# Altium schematic sheet BALANCED_CHARGE.SchDoc
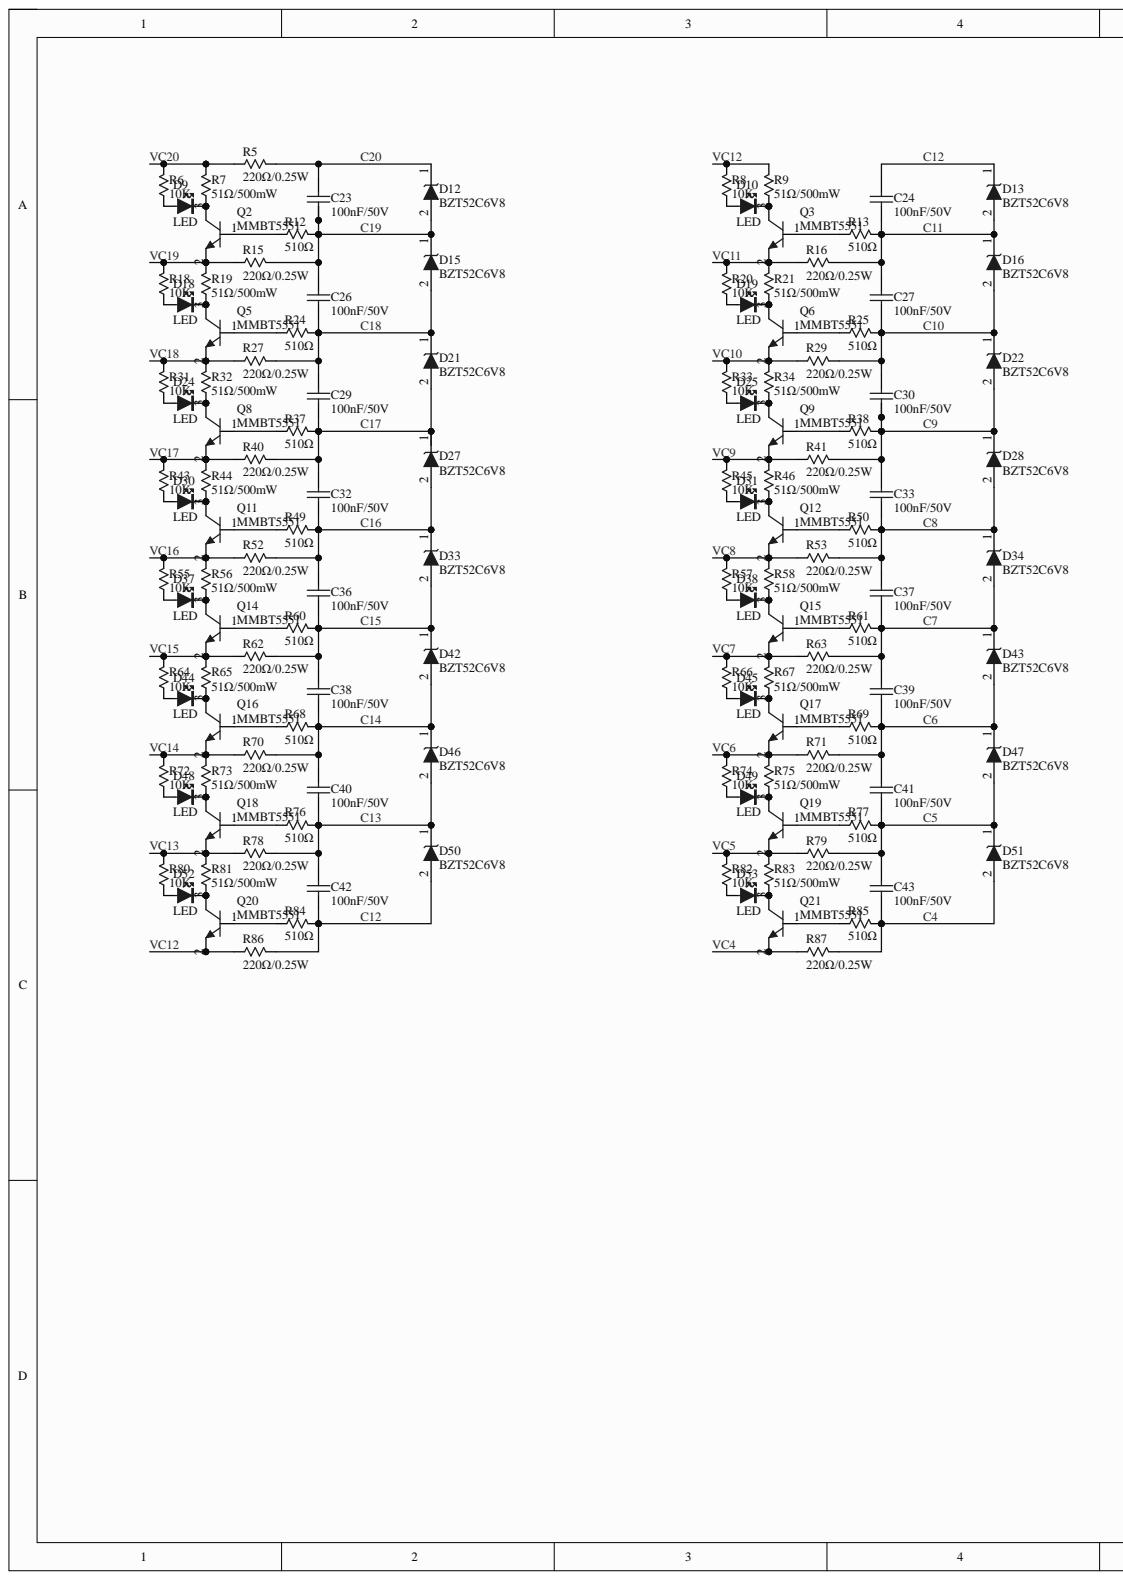
[diagram p0: BALANCED_CHARGE.SchDoc, left]
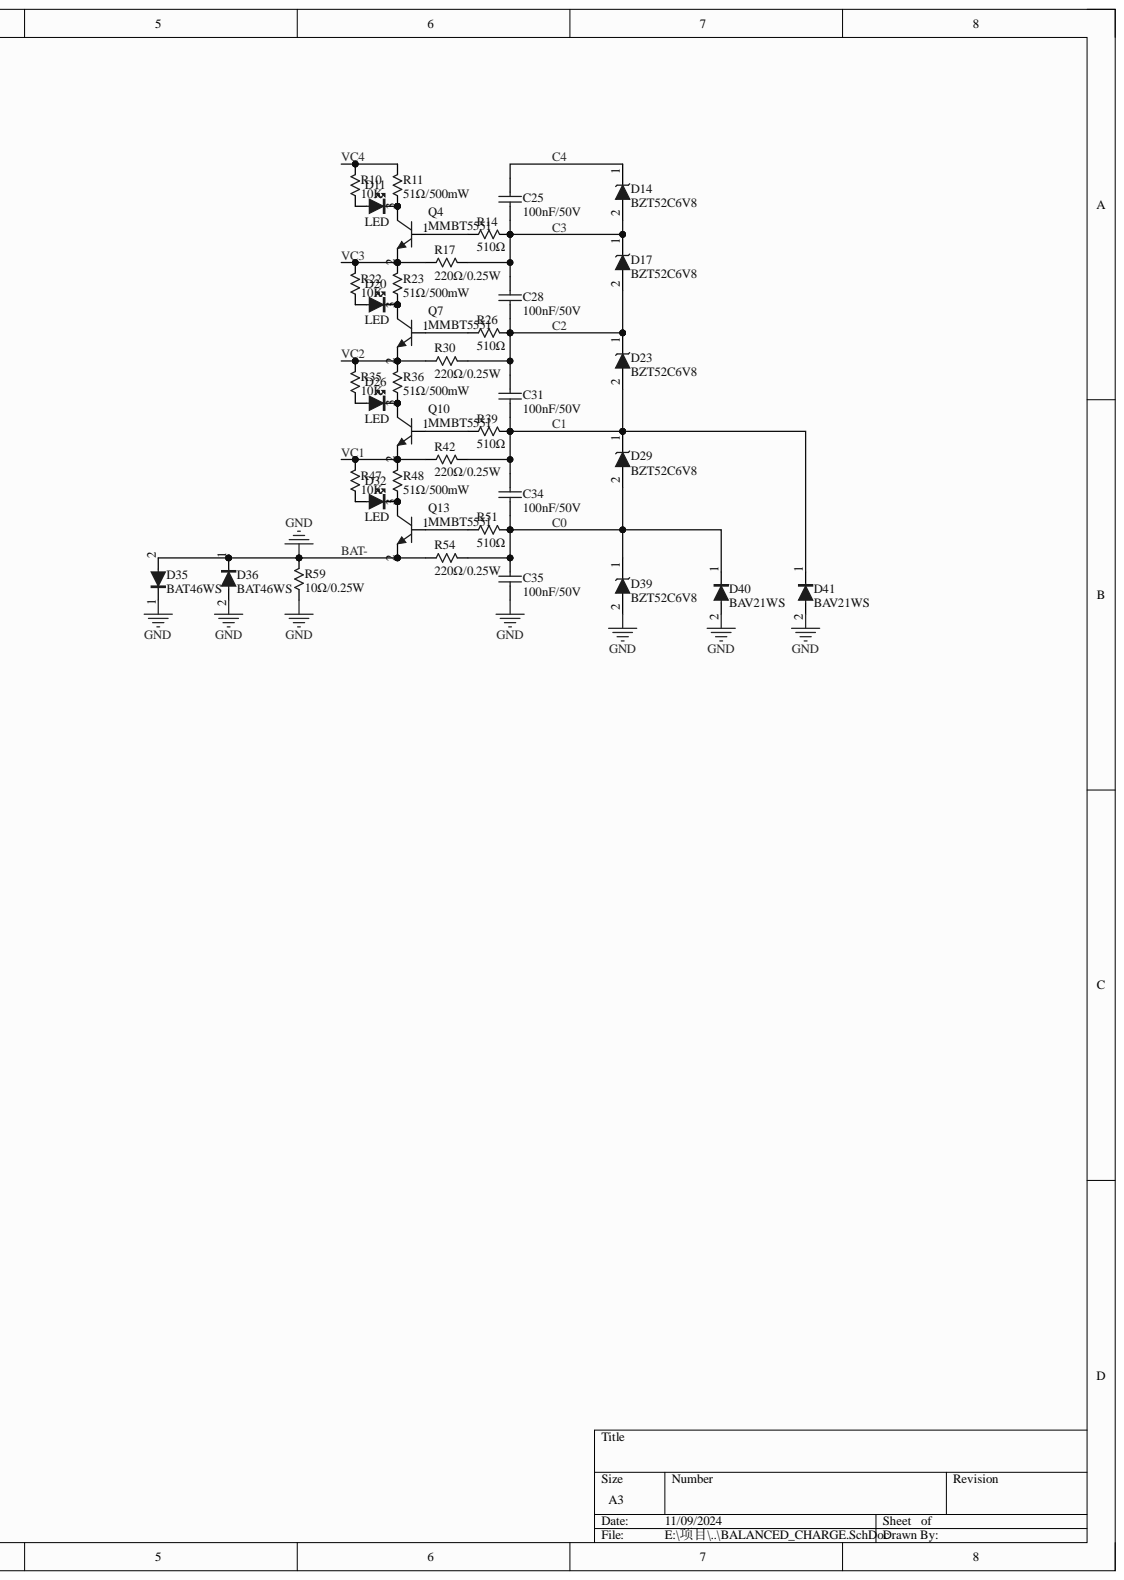
[diagram p1: BALANCED_CHARGE.SchDoc, right]

## Parts
C23  100nF/50V  [0603_C]
C24  100nF/50V  [0603_C]
C25  100nF/50V  [0603_C]
C26  100nF/50V  [0603_C]
C27  100nF/50V  [0603_C]
C28  100nF/50V  [0603_C]
C29  100nF/50V  [0603_C]
C30  100nF/50V  [0603_C]
C31  100nF/50V  [0603_C]
C32  100nF/50V  [0603_C]
C33  100nF/50V  [0603_C]
C34  100nF/50V  [0603_C]
C35  100nF/50V  [0603_C]
C36  100nF/50V  [0603_C]
C37  100nF/50V  [0603_C]
C38  100nF/50V  [0603_C]
C39  100nF/50V  [0603_C]
C40  100nF/50V  [0603_C]
C41  100nF/50V  [0603_C]
C42  100nF/50V  [0603_C]
C43  100nF/50V  [0603_C]
D9  LED  [LED-0603G]
D10  LED  [LED-0603G]
D11  LED  [LED-0603G]
D12  BZT52C6V8  [SOD-123]
D13  BZT52C6V8  [SOD-123]
D14  BZT52C6V8  [SOD-123]
D15  BZT52C6V8  [SOD-123]
D16  BZT52C6V8  [SOD-123]
D17  BZT52C6V8  [SOD-123]
D18  LED  [LED-0603G]
D19  LED  [LED-0603G]
D20  LED  [LED-0603G]
D21  BZT52C6V8  [SOD-123]
D22  BZT52C6V8  [SOD-123]
D23  BZT52C6V8  [SOD-123]
D24  LED  [LED-0603G]
D25  LED  [LED-0603G]
D26  LED  [LED-0603G]
D27  BZT52C6V8  [SOD-123]
D28  BZT52C6V8  [SOD-123]
D29  BZT52C6V8  [SOD-123]
D30  LED  [LED-0603G]
D31  LED  [LED-0603G]
D32  LED  [LED-0603G]
D33  BZT52C6V8  [SOD-123]
D34  BZT52C6V8  [SOD-123]
D35  BAT46WS  [SOD-323]
D36  BAT46WS  [SOD-323]
D37  LED  [LED-0603G]
D38  LED  [LED-0603G]
D39  BZT52C6V8  [SOD-123]
D40  BAV21WS  [SOD-323]
D41  BAV21WS  [SOD-323]
D42  BZT52C6V8  [SOD-123]
D43  BZT52C6V8  [SOD-123]
D44  LED  [LED-0603G]
D45  LED  [LED-0603G]
D46  BZT52C6V8  [SOD-123]
D47  BZT52C6V8  [SOD-123]
D48  LED  [LED-0603G]
D49  LED  [LED-0603G]
D50  BZT52C6V8  [SOD-123]
D51  BZT52C6V8  [SOD-123]
D52  LED  [LED-0603G]
D53  LED  [LED-0603G]
Q2  MMBT5551  [SOT-23-3]
Q3  MMBT5551  [SOT-23-3]
Q4  MMBT5551  [SOT-23-3]
Q5  MMBT5551  [SOT-23-3]
Q6  MMBT5551  [SOT-23-3]
Q7  MMBT5551  [SOT-23-3]
Q8  MMBT5551  [SOT-23-3]
Q9  MMBT5551  [SOT-23-3]
Q10  MMBT5551  [SOT-23-3]
Q11  MMBT5551  [SOT-23-3]
Q12  MMBT5551  [SOT-23-3]
Q13  MMBT5551  [SOT-23-3]
Q14  MMBT5551  [SOT-23-3]
Q15  MMBT5551  [SOT-23-3]
Q16  MMBT5551  [SOT-23-3]
Q17  MMBT5551  [SOT-23-3]
Q18  MMBT5551  [SOT-23-3]
Q19  MMBT5551  [SOT-23-3]
Q20  MMBT5551  [SOT-23-3]
Q21  MMBT5551  [SOT-23-3]
R5  220Ω/0.25W  [0603_R]
R6  10K  [0603_R]
R7  51Ω/500mW  [1206R]
R8  10K  [0603_R]
R9  51Ω/500mW  [1206R]
R10  10K  [0603_R]
R11  51Ω/500mW  [1206R]
R12  510Ω  [0603_R]
R13  510Ω  [0603_R]
R14  510Ω  [0603_R]
R15  220Ω/0.25W  [0603_R]
R16  220Ω/0.25W  [0603_R]
R17  220Ω/0.25W  [0603_R]
R18  10K  [0603_R]
R19  51Ω/500mW  [1206R]
R20  10K  [0603_R]
R21  51Ω/500mW  [1206R]
R22  10K  [0603_R]
R23  51Ω/500mW  [1206R]
R24  510Ω  [0603_R]
R25  510Ω  [0603_R]
R26  510Ω  [0603_R]
R27  220Ω/0.25W  [0603_R]
R29  220Ω/0.25W  [0603_R]
R30  220Ω/0.25W  [0603_R]
R31  10K  [0603_R]
R32  51Ω/500mW  [1206R]
R33  10K  [0603_R]
R34  51Ω/500mW  [1206R]
R35  10K  [0603_R]
R36  51Ω/500mW  [1206R]
R37  510Ω  [0603_R]
R38  510Ω  [0603_R]
R39  510Ω  [0603_R]
R40  220Ω/0.25W  [0603_R]
R41  220Ω/0.25W  [0603_R]
R42  220Ω/0.25W  [0603_R]
R43  10K  [0603_R]
R44  51Ω/500mW  [1206R]
R45  10K  [0603_R]
R46  51Ω/500mW  [1206R]
R47  10K  [0603_R]
R48  51Ω/500mW  [1206R]
R49  510Ω  [0603_R]
R50  510Ω  [0603_R]
R51  510Ω  [0603_R]
R52  220Ω/0.25W  [0603_R]
R53  220Ω/0.25W  [0603_R]
R54  220Ω/0.25W  [0603_R]
R55  10K  [0603_R]
R56  51Ω/500mW  [1206R]
R57  10K  [0603_R]
R58  51Ω/500mW  [1206R]
R59  10Ω/0.25W  [0603_R]
R60  510Ω  [0603_R]
R61  510Ω  [0603_R]
R62  220Ω/0.25W  [0603_R]
R63  220Ω/0.25W  [0603_R]
R64  10K  [0603_R]
R65  51Ω/500mW  [1206R]
R66  10K  [0603_R]
R67  51Ω/500mW  [1206R]
R68  510Ω  [0603_R]
R69  510Ω  [0603_R]
R70  220Ω/0.25W  [0603_R]
R71  220Ω/0.25W  [0603_R]
R72  10K  [0603_R]
R73  51Ω/500mW  [1206R]
R74  10K  [0603_R]
R75  51Ω/500mW  [1206R]
R76  510Ω  [0603_R]
R77  510Ω  [0603_R]
R78  220Ω/0.25W  [0603_R]
R79  220Ω/0.25W  [0603_R]
R80  10K  [0603_R]
R81  51Ω/500mW  [1206R]
R82  10K  [0603_R]
R83  51Ω/500mW  [1206R]
R84  510Ω  [0603_R]
R85  510Ω  [0603_R]
R86  220Ω/0.25W  [0603_R]
R87  220Ω/0.25W  [0603_R]

## Nets
BAT-: C35.2 D35.1 D35.2 D36.1 D36.2 D39.2 D40.2 D41.2 Q13.2 R54.2 R59.1 R59.2
C0: C34.2 C35.1 D29.2 D39.1 D40.1 R51.1 R54.1
C1: C31.2 C34.1 D23.2 D29.1 D41.1 R39.1 R42.1
C2: C28.2 C31.1 D17.2 D23.1 R26.1 R30.1
C3: C25.2 C28.1 D14.2 D17.1 R14.1 R17.1
C4: C25.1 C43.2 D14.1 D51.2 R85.1 R87.1
C5: C41.2 C43.1 D47.2 D51.1 R77.1 R79.1
C6: C39.2 C41.1 D43.2 D47.1 R69.1 R71.1
C7: C37.2 C39.1 D34.2 D43.1 R61.1 R63.1
C8: C33.2 C37.1 D28.2 D34.1 R50.1 R53.1
C9: C30.2 C33.1 D22.2 D28.1 R38.1 R41.1
C10: C27.2 C30.1 D16.2 D22.1 R25.1 R29.1
C11: C24.2 C27.1 D13.2 D16.1 R13.1 R16.1
C12: C24.1 C42.2 D13.1 D50.2 R84.1 R86.1
C13: C40.2 C42.1 D46.2 D50.1 R76.1 R78.1
C14: C38.2 C40.1 D42.2 D46.1 R68.1 R70.1
C15: C36.2 C38.1 D33.2 D42.1 R60.1 R62.1
C16: C32.2 C36.1 D27.2 D33.1 R49.1 R52.1
C17: C29.2 C32.1 D21.2 D27.1 R37.1 R40.1
C18: C26.2 C29.1 D15.2 D21.1 R24.1 R27.1
C19: C23.2 C26.1 D12.2 D15.1 R12.1 R15.1
C20: C23.1 D12.1 R5.1
VC1: Q10.2 R42.2 R47.1 R48.1
VC2: Q7.2 R30.2 R35.1 R36.1
VC3: Q4.2 R17.2 R22.1 R23.1
VC4: Q21.2 R10.1 R11.1 R87.2
VC5: Q19.2 R79.2 R82.1 R83.1
VC6: Q17.2 R71.2 R74.1 R75.1
VC7: Q15.2 R63.2 R66.1 R67.1
VC8: Q12.2 R53.2 R57.1 R58.1
VC9: Q9.2 R41.2 R45.1 R46.1
VC10: Q6.2 R29.2 R33.1 R34.1
VC11: Q3.2 R16.2 R20.1 R21.1
VC12: Q20.2 R8.1 R9.1 R86.2
VC13: Q18.2 R78.2 R80.1 R81.1
VC14: Q16.2 R70.2 R72.1 R73.1
VC15: Q14.2 R62.2 R64.1 R65.1
VC16: Q11.2 R52.2 R55.1 R56.1
VC17: Q8.2 R40.2 R43.1 R44.1
VC18: Q5.2 R27.2 R31.1 R32.1
VC19: Q2.2 R15.2 R18.1 R19.1
VC20: R5.2 R6.1 R7.1
NetD9_A: D9.A R6.2
NetD9_K: D9.K Q2.3 R7.2
NetD10_A: D10.A R8.2
NetD10_K: D10.K Q3.3 R9.2
NetD11_A: D11.A R10.2
NetD11_K: D11.K Q4.3 R11.2
NetD18_A: D18.A R18.2
NetD18_K: D18.K Q5.3 R19.2
NetD19_A: D19.A R20.2
NetD19_K: D19.K Q6.3 R21.2
NetD20_A: D20.A R22.2
NetD20_K: D20.K Q7.3 R23.2
NetD24_A: D24.A R31.2
NetD24_K: D24.K Q8.3 R32.2
NetD25_A: D25.A R33.2
NetD25_K: D25.K Q9.3 R34.2
NetD26_A: D26.A R35.2
NetD26_K: D26.K Q10.3 R36.2
NetD30_A: D30.A R43.2
NetD30_K: D30.K Q11.3 R44.2
NetD31_A: D31.A R45.2
NetD31_K: D31.K Q12.3 R46.2
NetD32_A: D32.A R47.2
NetD32_K: D32.K Q13.3 R48.2
NetD37_A: D37.A R55.2
NetD37_K: D37.K Q14.3 R56.2
NetD38_A: D38.A R57.2
NetD38_K: D38.K Q15.3 R58.2
NetD44_A: D44.A R64.2
NetD44_K: D44.K Q16.3 R65.2
NetD45_A: D45.A R66.2
NetD45_K: D45.K Q17.3 R67.2
NetD48_A: D48.A R72.2
NetD48_K: D48.K Q18.3 R73.2
NetD49_A: D49.A R74.2
NetD49_K: D49.K Q19.3 R75.2
NetD52_A: D52.A R80.2
NetD52_K: D52.K Q20.3 R81.2
NetD53_A: D53.A R82.2
NetD53_K: D53.K Q21.3 R83.2
NetQ2_1: Q2.1 R12.2
NetQ3_1: Q3.1 R13.2
NetQ4_1: Q4.1 R14.2
NetQ5_1: Q5.1 R24.2
NetQ6_1: Q6.1 R25.2
NetQ7_1: Q7.1 R26.2
NetQ8_1: Q8.1 R37.2
NetQ9_1: Q9.1 R38.2
NetQ10_1: Q10.1 R39.2
NetQ11_1: Q11.1 R49.2
NetQ12_1: Q12.1 R50.2
NetQ13_1: Q13.1 R51.2
NetQ14_1: Q14.1 R60.2
NetQ15_1: Q15.1 R61.2
NetQ16_1: Q16.1 R68.2
NetQ17_1: Q17.1 R69.2
NetQ18_1: Q18.1 R76.2
NetQ19_1: Q19.1 R77.2
NetQ20_1: Q20.1 R84.2
NetQ21_1: Q21.1 R85.2

## Library data of the parts
C23-C43 = Cap: Capacitor; Component Kind: Standard; LatestRevisionDate: 17-Jul-2002; LatestRevisionNote: Re-released for DXP Platform.; PackageReference: RAD-0.3; Published: 8-Jun-2000; Signal Integrity: Cap; Simulation: CAP; Value: 100nF/50V; Library Name: UP-ROBOT Devices.SchLib; Publisher: Altium Limited
D12-D17 D21-D23 D27-D29 D33 D34 D39 D42 D43 D46 D47 D50 D51 = BZT52C16S: Component Kind: Standard; Library Name: DVC1124_20S.SchLib
D35 D36 D40 D41 = 1N4148W: Component Kind: Standard; Library Name: DVC1124_20S.SchLib
Q2-Q21 = NPN: Component Kind: Standard; Library Name: Three_Axis_Arm_Control_V1.1.SchLib
R5-R27 R29-R87 = Res: Resistor; Component Kind: Standard; LatestRevisionDate: 17-Jul-2002; LatestRevisionNote: Re-released for DXP Platform.; PackageReference: AXIAL-0.3; Published: 8-Jun-2000; Signal Integrity: Res; Simulation: RESISTOR; Library Name: UP-ROBOT Devices.SchLib; Publisher: Altium Limited
  R5 R15-R17 R27 R29 R30 R40-R42 R52-R54 R62 R63 R70 R71 R78 R79 R86 R87: Value: 220Ω/0.25W
  R6 R8 R10 R18 R20 R22 R31 R33 R35 R43 R45 R47 R55 R57 R64 R66 R72 R74 R80 R82: Value: 10K
  R7 R9 R11 R19 R21 R23 R32 R34 R36 R44 R46 R48 R56 R58 R65 R67 R73 R75 R81 R83: Value: 51Ω/500mW
  R12-R14 R24-R26 R37-R39 R49-R51 R60 R61 R68 R69 R76 R77 R84 R85: Value: 510Ω
  R59: Value: 10Ω/0.25W
D9-D11 D18-D20 D24-D26 D30-D32 D37 D38 D44 D45 D48 D49 D52 D53 = LED: Component Kind: Standard; Library Name: UP-ROBOT Devices.SchLib
